# Altium schematic sheet Matrix.SchDoc
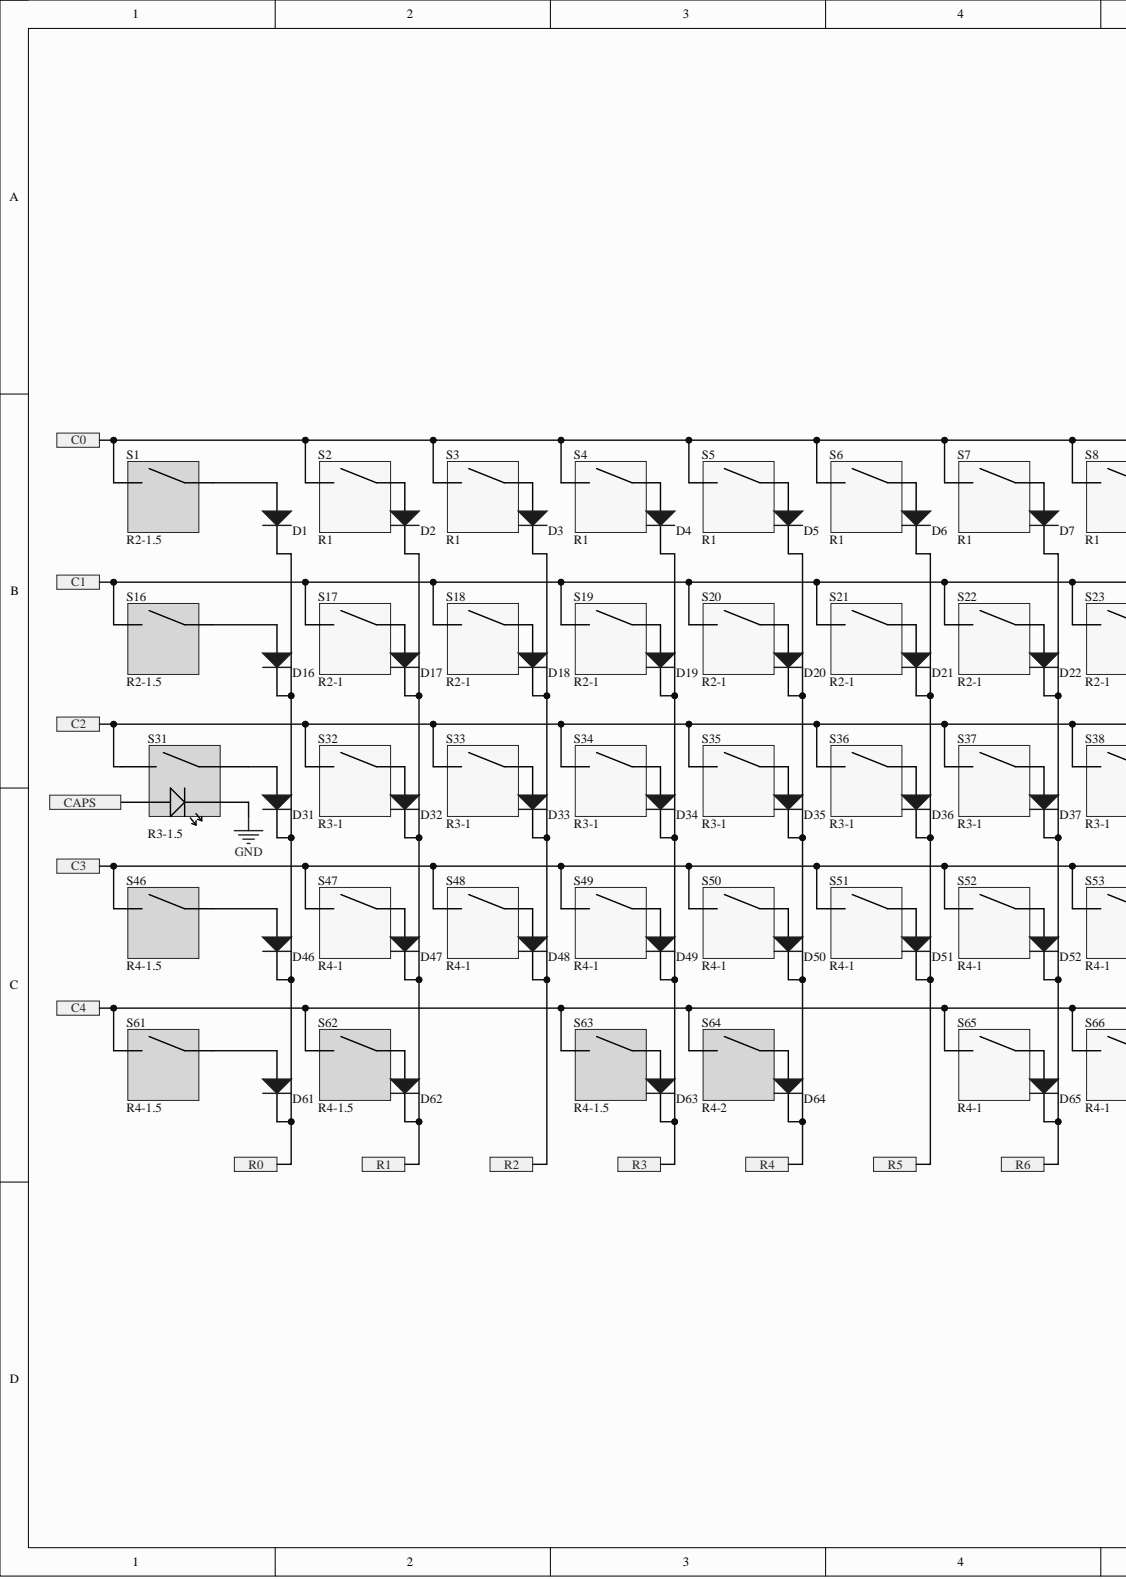
[diagram p0: Matrix.SchDoc, left]
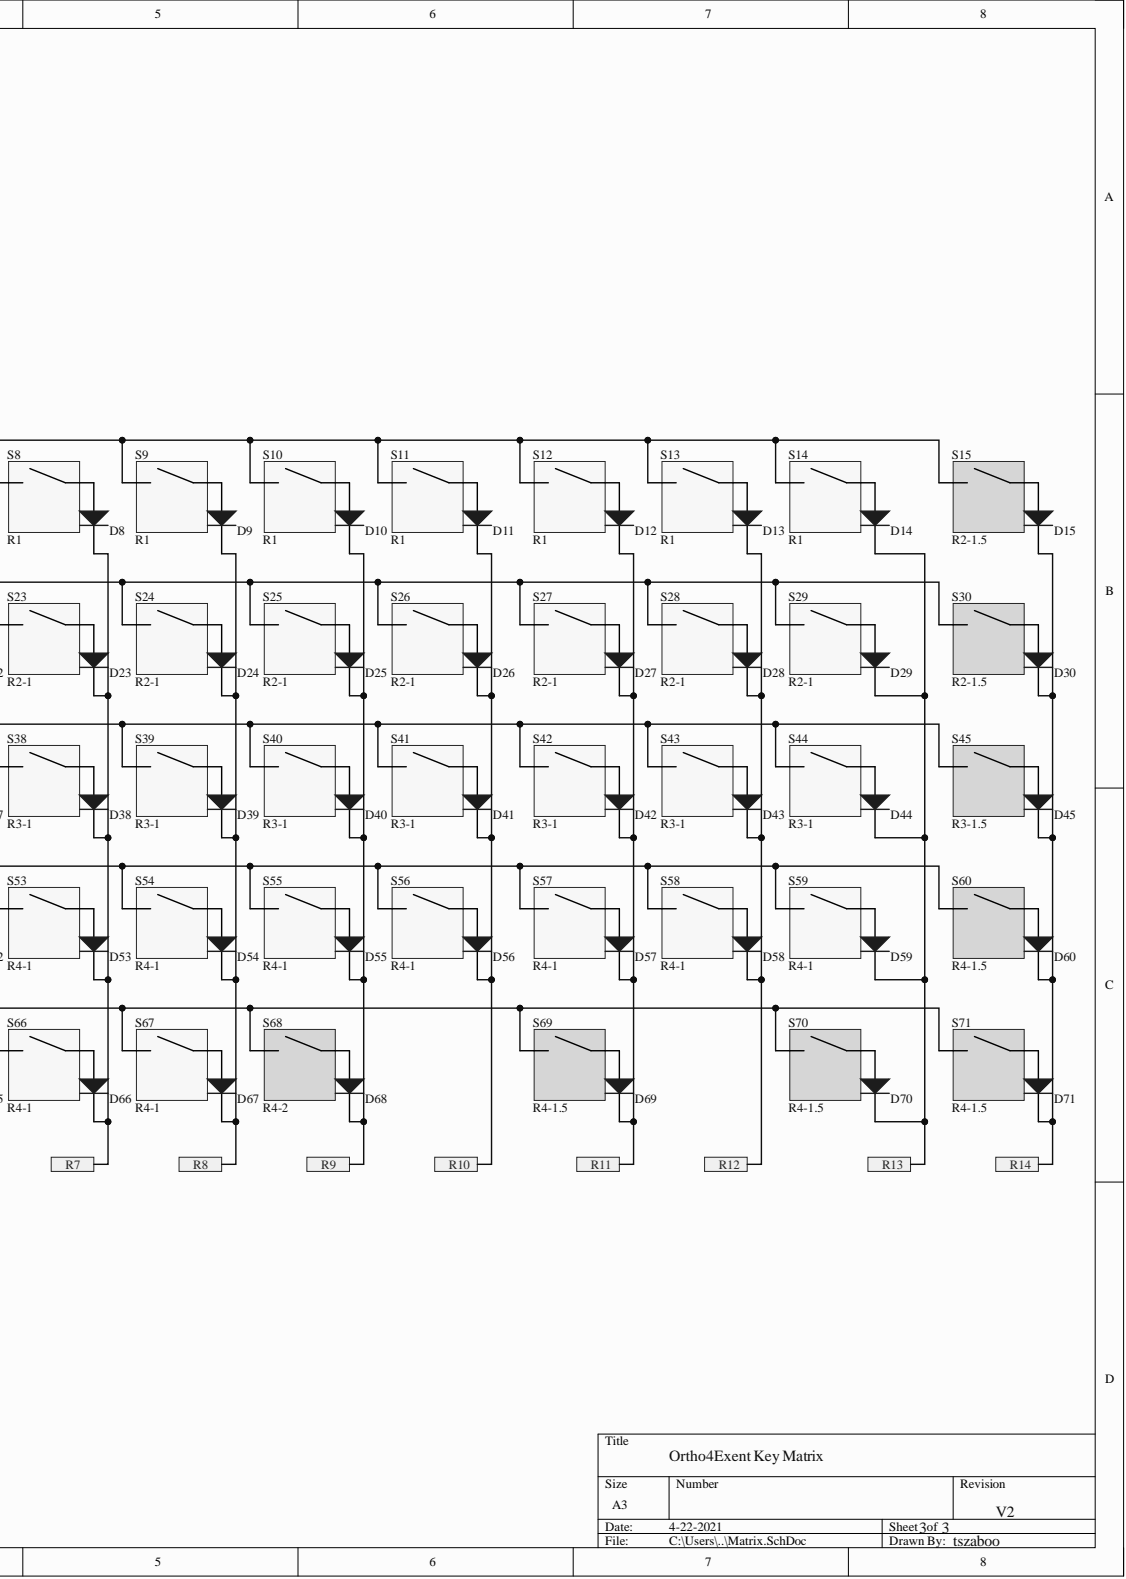
[diagram p1: Matrix.SchDoc, right]

## Parts
D1  1N4148WS  [SOD323FL]  (value not drawn)
D2  1N4148WS  [SOD323FL]  (value not drawn)
D3  1N4148WS  [SOD323FL]  (value not drawn)
D4  1N4148WS  [SOD323FL]  (value not drawn)
D5  1N4148WS  [SOD323FL]  (value not drawn)
D6  1N4148WS  [SOD323FL]  (value not drawn)
D7  1N4148WS  [SOD323FL]  (value not drawn)
D8  1N4148WS  [SOD323FL]  (value not drawn)
D9  1N4148WS  [SOD323FL]  (value not drawn)
D10  1N4148WS  [SOD323FL]  (value not drawn)
D11  1N4148WS  [SOD323FL]  (value not drawn)
D12  1N4148WS  [SOD323FL]  (value not drawn)
D13  1N4148WS  [SOD323FL]  (value not drawn)
D14  1N4148WS  [SOD323FL]  (value not drawn)
D15  1N4148WS  [SOD323FL]  (value not drawn)
D16  1N4148WS  [SOD323FL]  (value not drawn)
D17  1N4148WS  [SOD323FL]  (value not drawn)
D18  1N4148WS  [SOD323FL]  (value not drawn)
D19  1N4148WS  [SOD323FL]  (value not drawn)
D20  1N4148WS  [SOD323FL]  (value not drawn)
D21  1N4148WS  [SOD323FL]  (value not drawn)
D22  1N4148WS  [SOD323FL]  (value not drawn)
D23  1N4148WS  [SOD323FL]  (value not drawn)
D24  1N4148WS  [SOD323FL]  (value not drawn)
D25  1N4148WS  [SOD323FL]  (value not drawn)
D26  1N4148WS  [SOD323FL]  (value not drawn)
D27  1N4148WS  [SOD323FL]  (value not drawn)
D28  1N4148WS  [SOD323FL]  (value not drawn)
D29  1N4148WS  [SOD323FL]  (value not drawn)
D30  1N4148WS  [SOD323FL]  (value not drawn)
D31  1N4148WS  [SOD323FL]  (value not drawn)
D32  1N4148WS  [SOD323FL]  (value not drawn)
D33  1N4148WS  [SOD323FL]  (value not drawn)
D34  1N4148WS  [SOD323FL]  (value not drawn)
D35  1N4148WS  [SOD323FL]  (value not drawn)
D36  1N4148WS  [SOD323FL]  (value not drawn)
D37  1N4148WS  [SOD323FL]  (value not drawn)
D38  1N4148WS  [SOD323FL]  (value not drawn)
D39  1N4148WS  [SOD323FL]  (value not drawn)
D40  1N4148WS  [SOD323FL]  (value not drawn)
D41  1N4148WS  [SOD323FL]  (value not drawn)
D42  1N4148WS  [SOD323FL]  (value not drawn)
D43  1N4148WS  [SOD323FL]  (value not drawn)
D44  1N4148WS  [SOD323FL]  (value not drawn)
D45  1N4148WS  [SOD323FL]  (value not drawn)
D46  1N4148WS  [SOD323FL]  (value not drawn)
D47  1N4148WS  [SOD323FL]  (value not drawn)
D48  1N4148WS  [SOD323FL]  (value not drawn)
D49  1N4148WS  [SOD323FL]  (value not drawn)
D50  1N4148WS  [SOD323FL]  (value not drawn)
D51  1N4148WS  [SOD323FL]  (value not drawn)
D52  1N4148WS  [SOD323FL]  (value not drawn)
D53  1N4148WS  [SOD323FL]  (value not drawn)
D54  1N4148WS  [SOD323FL]  (value not drawn)
D55  1N4148WS  [SOD323FL]  (value not drawn)
D56  1N4148WS  [SOD323FL]  (value not drawn)
D57  1N4148WS  [SOD323FL]  (value not drawn)
D58  1N4148WS  [SOD323FL]  (value not drawn)
D59  1N4148WS  [SOD323FL]  (value not drawn)
D60  1N4148WS  [SOD323FL]  (value not drawn)
D61  1N4148WS  [SOD323FL]  (value not drawn)
D62  1N4148WS  [SOD323FL]  (value not drawn)
D63  1N4148WS  [SOD323FL]  (value not drawn)
D64  1N4148WS  [SOD323FL]  (value not drawn)
D65  1N4148WS  [SOD323FL]  (value not drawn)
D66  1N4148WS  [SOD323FL]  (value not drawn)
D67  1N4148WS  [SOD323FL]  (value not drawn)
D68  1N4148WS  [SOD323FL]  (value not drawn)
D69  1N4148WS  [SOD323FL]  (value not drawn)
D70  1N4148WS  [SOD323FL]  (value not drawn)
D71  1N4148WS  [SOD323FL]  (value not drawn)
S1  R2-1.5  [R2-1.5 NOLED]
S2  R1  [R1 NOLED]
S3  R1  [R1 NOLED]
S4  R1  [R1 NOLED]
S5  R1  [R1 NOLED]
S6  R1  [R1 NOLED]
S7  R1  [R1 NOLED]
S8  R1  [R1-1 NOLED 180]
S9  R1  [R1 NOLED]
S10  R1  [R1 NOLED]
S11  R1  [R1 NOLED]
S12  R1  [R1 NOLED]
S13  R1  [R1 NOLED]
S14  R1  [R1 NOLED]
S15  R2-1.5  [R2-1.5 NOLED]
S16  R2-1.5  [R2-1.5 NOLED]
S17  R2-1  [R2-1 NOLED]
S18  R2-1  [R2-1 NOLED]
S19  R2-1  [R2-1 NOLED]
S20  R2-1  [R2-1 NOLED]
S21  R2-1  [R2-1 NOLED]
S22  R2-1  [R2-1 NOLED]
S23  R2-1  [R2-1 NOLED]
S24  R2-1  [R2-1 NOLED]
S25  R2-1  [R2-1 NOLED]
S26  R2-1  [R2-1 NOLED]
S27  R2-1  [R2-1 NOLED]
S28  R2-1  [R2-1 NOLED]
S29  R2-1  [R2-1 NOLED]
S30  R2-1.5  [R2-1.5 NOLED]
S31  R3-1.5  [R3-1.5]
S32  R3-1  [R3-1 NOLED]
S33  R3-1  [R3-1 NOLED]
S34  R3-1  [R3-1 NOLED]
S35  R3-1  [R3-1 NOLED]
S36  R3-1  [R3-1 NOLED]
S37  R3-1  [R3-1 NOLED]
S38  R3-1  [R3-1 NOLED]
S39  R3-1  [R3-1 NOLED]
S40  R3-1  [R3-1 NOLED]
S41  R3-1  [R3-1 NOLED]
S42  R3-1  [R3-1 NOLED]
S43  R3-1  [R3-1 NOLED]
S44  R3-1  [R3-1 NOLED]
S45  R3-1.5  [R3-1.5 NOLED]
S46  R4-1.5  [R4-1.5 NOLED]
S47  R4-1  [R4-1 NOLED]
S48  R4-1  [R4-1 NOLED]
S49  R4-1  [R4-1 NOLED]
S50  R4-1  [R4-1 NOLED]
S51  R4-1  [R4-1 NOLED]
S52  R4-1  [R4-1 NOLED]
S53  R4-1  [R4-1 NOLED]
S54  R4-1  [R4-1 NOLED]
S55  R4-1  [R4-1 NOLED]
S56  R4-1  [R4-1 NOLED]
S57  R4-1  [R4-1 NOLED]
S58  R4-1  [R4-1 NOLED]
S59  R4-1  [R4-1 NOLED]
S60  R4-1.5  [R4-1.5 NOLED]
S61  R4-1.5  [R4-1.5 NOLED]  (no BOM)
S62  R4-1.5  [R4-1.5 NOLED]
S63  R4-1.5  [R4-1.5 NOLED]
S64  R4-2  [R4-2 NOLED 180]
S65  R4-1  [R4-1 NOLED]
S66  R4-1  [R4-1 NOLED]
S67  R4-1  [R4-1 NOLED]
S68  R4-2  [R4-2 NOLED 180]
S69  R4-1.5  [R4-1.5 NOLED]
S70  R4-1.5  [R4-1.5 NOLED]
S71  R4-1.5  [R4-1.5 NOLED]

## Ports
C0, C1, C2, C3, C4, CAPS, R0, R1, R2, R3, R4, R5, R6, R7, R8, R9, R10, R11, R12, R13, R14

## Nets
GND: S31.3
C0: S1.1 S2.1 S3.1 S4.1 S5.1 S6.1 S7.1 S8.1 S9.1 S10.1 S11.1 S12.1 S13.1 S14.1 S15.1 ; port C0
C1: S16.1 S17.1 S18.1 S19.1 S20.1 S21.1 S22.1 S23.1 S24.1 S25.1 S26.1 S27.1 S28.1 S29.1 S30.1 ; port C1
C2: S31.1 S32.1 S33.1 S34.1 S35.1 S36.1 S37.1 S38.1 S39.1 S40.1 S41.1 S42.1 S43.1 S44.1 S45.1 ; port C2
C3: S46.1 S47.1 S48.1 S49.1 S50.1 S51.1 S52.1 S53.1 S54.1 S55.1 S56.1 S57.1 S58.1 S59.1 S60.1 ; port C3
C4: S61.1 S62.1 S63.1 S64.1 S65.1 S66.1 S67.1 S68.1 S69.1 S70.1 S71.1 ; port C4
CAPS: S31.4 ; port CAPS
R0: D1.2 D16.2 D31.2 D46.2 D61.2 ; port R0
R1: D2.2 D17.2 D32.2 D47.2 D62.2 ; port R1
R2: D3.2 D18.2 D33.2 D48.2 ; port R2
R3: D4.2 D19.2 D34.2 D49.2 D63.2 ; port R3
R4: D5.2 D20.2 D35.2 D50.2 D64.2 ; port R4
R5: D6.2 D21.2 D36.2 D51.2 ; port R5
R6: D7.2 D22.2 D37.2 D52.2 D65.2 ; port R6
R7: D8.2 D23.2 D38.2 D53.2 D66.2 ; port R7
R8: D9.2 D24.2 D39.2 D54.2 D67.2 ; port R8
R9: D10.2 D25.2 D40.2 D55.2 D68.2 ; port R9
R10: D11.2 D26.2 D41.2 D56.2 ; port R10
R11: D12.2 D27.2 D42.2 D57.2 D69.2 ; port R11
R12: D13.2 D28.2 D43.2 D58.2 ; port R12
R13: D14.2 D29.2 D44.2 D59.2 D70.2 ; port R13
R14: D15.2 D30.2 D45.2 D60.2 D71.2 ; port R14
NetD1_1: D1.1 S1.2
NetD2_1: D2.1 S2.2
NetD3_1: D3.1 S3.2
NetD4_1: D4.1 S4.2
NetD5_1: D5.1 S5.2
NetD6_1: D6.1 S6.2
NetD7_1: D7.1 S7.2
NetD8_1: D8.1 S8.2
NetD9_1: D9.1 S9.2
NetD10_1: D10.1 S10.2
NetD11_1: D11.1 S11.2
NetD12_1: D12.1 S12.2
NetD13_1: D13.1 S13.2
NetD14_1: D14.1 S14.2
NetD15_1: D15.1 S15.2
NetD16_1: D16.1 S16.2
NetD17_1: D17.1 S17.2
NetD18_1: D18.1 S18.2
NetD19_1: D19.1 S19.2
NetD20_1: D20.1 S20.2
NetD21_1: D21.1 S21.2
NetD22_1: D22.1 S22.2
NetD23_1: D23.1 S23.2
NetD24_1: D24.1 S24.2
NetD25_1: D25.1 S25.2
NetD26_1: D26.1 S26.2
NetD27_1: D27.1 S27.2
NetD28_1: D28.1 S28.2
NetD29_1: D29.1 S29.2
NetD30_1: D30.1 S30.2
NetD31_1: D31.1 S31.2
NetD32_1: D32.1 S32.2
NetD33_1: D33.1 S33.2
NetD34_1: D34.1 S34.2
NetD35_1: D35.1 S35.2
NetD36_1: D36.1 S36.2
NetD37_1: D37.1 S37.2
NetD38_1: D38.1 S38.2
NetD39_1: D39.1 S39.2
NetD40_1: D40.1 S40.2
NetD41_1: D41.1 S41.2
NetD42_1: D42.1 S42.2
NetD43_1: D43.1 S43.2
NetD44_1: D44.1 S44.2
NetD45_1: D45.1 S45.2
NetD46_1: D46.1 S46.2
NetD47_1: D47.1 S47.2
NetD48_1: D48.1 S48.2
NetD49_1: D49.1 S49.2
NetD50_1: D50.1 S50.2
NetD51_1: D51.1 S51.2
NetD52_1: D52.1 S52.2
NetD53_1: D53.1 S53.2
NetD54_1: D54.1 S54.2
NetD55_1: D55.1 S55.2
NetD56_1: D56.1 S56.2
NetD57_1: D57.1 S57.2
NetD58_1: D58.1 S58.2
NetD59_1: D59.1 S59.2
NetD60_1: D60.1 S60.2
NetD61_1: D61.1 S61.2
NetD62_1: D62.1 S62.2
NetD63_1: D63.1 S63.2
NetD64_1: D64.1 S64.2
NetD65_1: D65.1 S65.2
NetD66_1: D66.1 S66.2
NetD67_1: D67.1 S67.2
NetD68_1: D68.1 S68.2
NetD69_1: D69.1 S69.2
NetD70_1: D70.1 S70.2
NetD71_1: D71.1 S71.2

## Library data of the parts
D1-D71 = 1N4148WS: Default Diode; MANUF: ON Semi; COMPATIBLES: True; Database Table Name: SOD323$; DESCIPTION: DIODE GEN PURP 80V 125MA SOD323; GENERIC: 1N4148; NoPins: 2; Component Kind: Standard; DIGIKEY: 1N4148WSFSTR-ND; FARNELL: 2453270; Library Name: Rectifier.DbLib; MANUFCODE: 1N4148WS; PACKAGE: SOD323-FL; SMD: True
S1 S15 S16 S30 = R2-1.5: Component Kind: Standard; Library Name: MX-NOLED.SchLib
S2-S14 = R1: Component Kind: Standard; Library Name: MX-NOLED.SchLib
S17-S29 = R2-1: Component Kind: Standard; Library Name: MX-NOLED.SchLib
S31 S45 = R3-1.5: Component Kind: Standard
  S31: Library Name: MX.SchLib
  S45: Library Name: MX-NOLED.SchLib
S32-S44 = R3-1: Component Kind: Standard; Library Name: MX-NOLED.SchLib
S46 S60-S63 S69-S71 = R4-1.5: Library Name: MX-NOLED.SchLib
  S46 S60 S62 S63 S69-S71: Component Kind: Standard
S47-S59 S65-S67 = R4-1: Component Kind: Standard; Library Name: MX-NOLED.SchLib
S64 S68 = R4-2: Component Kind: Standard; Library Name: MX-NOLED.SchLib
